# Altium schematic sheet SH2 - MOTOR CONTROLLER.SchDoc
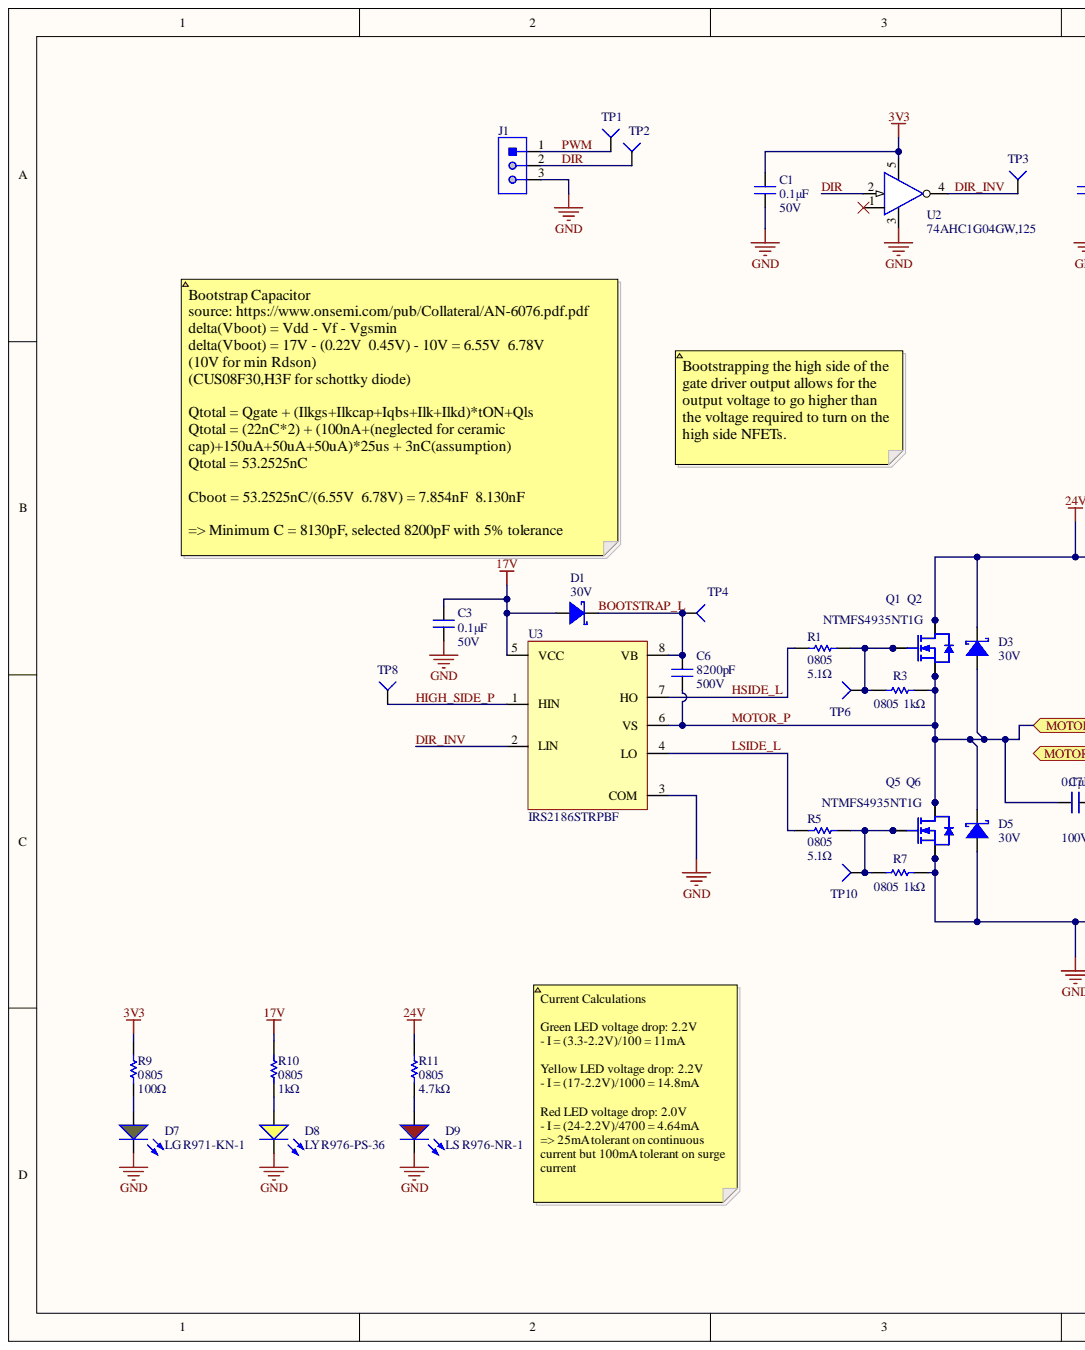
[diagram p0: SH2 - MOTOR CONTROLLER.SchDoc, left]
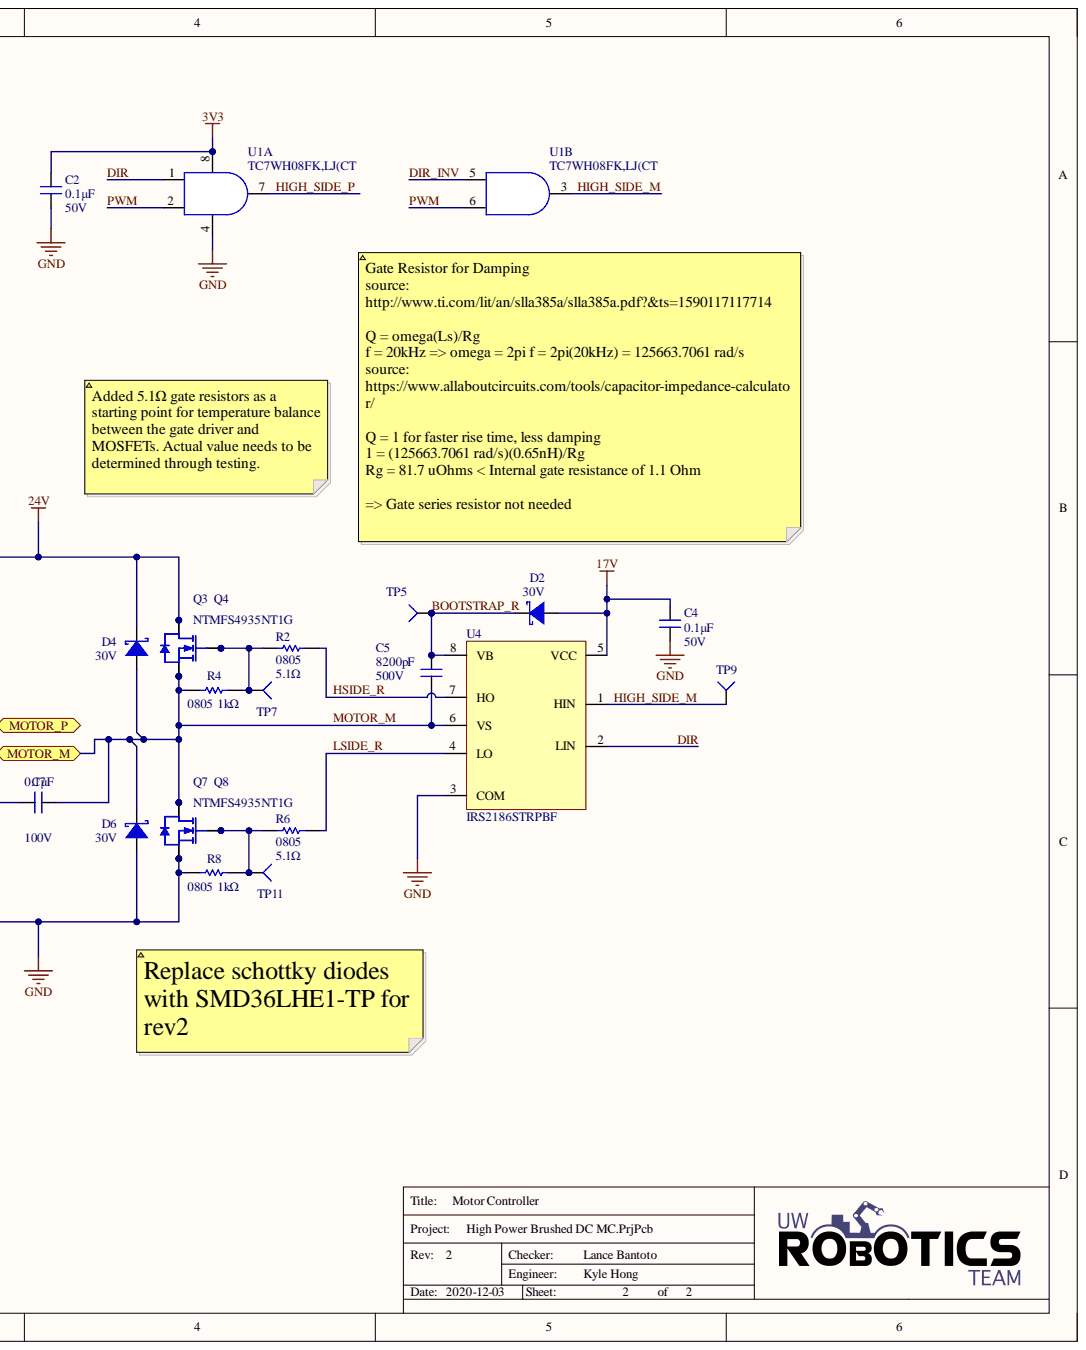
[diagram p1: SH2 - MOTOR CONTROLLER.SchDoc, right]

## Parts
C1  0.1μF  Voltage Rating: 50V  [CAP2012]
C2  0.1μF  Voltage Rating: 50V  [CAP2012]
C3  0.1μF  Voltage Rating: 50V  [CAP2012]
C4  0.1μF  Voltage Rating: 50V  [CAP2012]
C5  value 8200pF  Voltage Rating: 500V  [CAP1206]  (hidden comment: CAP_8200pF_500V_1206)
C6  value 8200pF  Voltage Rating: 500V  [CAP1206]  (hidden comment: CAP_8200pF_500V_1206)
C7  value 0.1μF  Voltage Rating: 100V  [MKS2D031001A00MSSD]  (hidden comment: CAP_0.1uF_100V_FILM)
D1  Vr (Max): 30V  [SOD-323_UNIDIR]  (hidden comment: DIO_SCHOTTKY_30V_800mA)
D2  Vr (Max): 30V  [SOD-323_UNIDIR]  (hidden comment: DIO_SCHOTTKY_30V_800mA)
D3  Vr (Max): 30V  [SOD-323_UNIDIR]  (hidden comment: DIO_SCHOTTKY_30V_800mA)
D4  Vr (Max): 30V  [SOD-323_UNIDIR]  (hidden comment: DIO_SCHOTTKY_30V_800mA)
D5  Vr (Max): 30V  [SOD-323_UNIDIR]  (hidden comment: DIO_SCHOTTKY_30V_800mA)
D6  Vr (Max): 30V  [SOD-323_UNIDIR]  (hidden comment: DIO_SCHOTTKY_30V_800mA)
D7  LG R971-KN-1  [LED_0805_GREEN]
D8  LY R976-PS-36  [LED_0805_YELLOW]
D9  LS R976-NR-1  [LED_0805_RED]
J1  CON_3M_TERM  [1935174]  (value not drawn)
Q1  NTMFS4935NT1G  [SO8-FL]
Q2  NTMFS4935NT1G  [SO8-FL]
Q3  NTMFS4935NT1G  [SO8-FL]
Q4  NTMFS4935NT1G  [SO8-FL]
Q5  NTMFS4935NT1G  [SO8-FL]
Q6  NTMFS4935NT1G  [SO8-FL]
Q7  NTMFS4935NT1G  [SO8-FL]
Q8  NTMFS4935NT1G  [SO8-FL]
R1  value 5.1Ω  Case Code (Imperial): 0805  [RESC2012X60N]  (hidden comment: RES_5.1Ω_0805)
R2  value 5.1Ω  Case Code (Imperial): 0805  [RESC2012X60N]  (hidden comment: RES_5.1Ω_0805)
R3  1kΩ  Case Code (Imperial): 0805  [RESC2012X60N]
R4  1kΩ  Case Code (Imperial): 0805  [RESC2012X60N]
R5  value 5.1Ω  Case Code (Imperial): 0805  [RESC2012X60N]  (hidden comment: RES_5.1Ω_0805)
R6  value 5.1Ω  Case Code (Imperial): 0805  [RESC2012X60N]  (hidden comment: RES_5.1Ω_0805)
R7  1kΩ  Case Code (Imperial): 0805  [RESC2012X60N]
R8  1kΩ  Case Code (Imperial): 0805  [RESC2012X60N]
R9  value 100Ω  Case Code (Imperial): 0805  [RESC2012X60N]  (hidden comment: RES_100Ω_0805)
R10  1kΩ  Case Code (Imperial): 0805  [RESC2012X60N]
R11  value 4.7kΩ  Case Code (Imperial): 0805  [RESC2012X60N]  (hidden comment: RES_4.7kΩ_0805)
TP1  TESTPOINT_1MM_CIRCLE  [TESTPOINT_CIRCLE_1MM]  (value not drawn)  (no BOM)
TP2  TESTPOINT_1MM_CIRCLE  [TESTPOINT_CIRCLE_1MM]  (value not drawn)  (no BOM)
TP3  TESTPOINT_1MM_CIRCLE  [TESTPOINT_CIRCLE_1MM]  (value not drawn)  (no BOM)
TP4  TESTPOINT_1MM_CIRCLE  [TESTPOINT_CIRCLE_1MM]  (value not drawn)  (no BOM)
TP5  TESTPOINT_1MM_CIRCLE  [TESTPOINT_CIRCLE_1MM]  (value not drawn)  (no BOM)
TP6  TESTPOINT_1MM_CIRCLE  [TESTPOINT_CIRCLE_1MM]  (value not drawn)  (no BOM)
TP7  TESTPOINT_1MM_CIRCLE  [TESTPOINT_CIRCLE_1MM]  (value not drawn)  (no BOM)
TP8  TESTPOINT_1MM_CIRCLE  [TESTPOINT_CIRCLE_1MM]  (value not drawn)  (no BOM)
TP9  TESTPOINT_1MM_CIRCLE  [TESTPOINT_CIRCLE_1MM]  (value not drawn)  (no BOM)
TP10  TESTPOINT_1MM_CIRCLE  [TESTPOINT_CIRCLE_1MM]  (value not drawn)  (no BOM)
TP11  TESTPOINT_1MM_CIRCLE  [TESTPOINT_CIRCLE_1MM]  (value not drawn)  (no BOM)
U1  TC7WH08FK,LJ(CT  [8-VFSOP]  (parts A,B)
U2  74AHC1G04GW,125  [SOT353]
U3  IRS2186STRPBF  [8-SOIC_M]
U4  IRS2186STRPBF  [8-SOIC_M]

## Ports
MOTOR_M, MOTOR_P

## Nets
3V3: C1.2 C2.2 R9.2 U1.8 U2.5
17V: C3.2 C4.2 D1.1 D2.1 R10.2 U3.5(VCC) U4.5(VCC)
24V: D3.2 D4.2 Q1.3 Q2.3 Q3.3 Q4.3 R11.2
GND: C1.1 C2.1 C3.1 C4.1 D5.1 D6.1 D7.2 D8.2 D9.2 J1.3 Q5.1 Q6.1 Q7.1 Q8.1 R7.2 R8.1 U1.4 U2.3 U3.3(COM) U4.3(COM)
BOOTSTRAP_L: C6.2 D1.2 TP4.1 U3.8(VB)
BOOTSTRAP_R: C5.2 D2.2 TP5.1 U4.8(VB)
DIR: J1.2 TP2.1 U1.1 U2.2 U4.2(LIN)
DIR_INV: TP3.1 U1.5 U2.4 U3.2(LIN)
HIGH_SIDE_M: TP9.1 U1.3 U4.1(HIN)
HIGH_SIDE_P: TP8.1 U1.7 U3.1(HIN)
HSIDE_L: R1.1 U3.7(HO)
HSIDE_R: R2.2 U4.7(HO)
LSIDE_L: R5.1 U3.4(LO)
LSIDE_R: R6.2 U4.4(LO)
MOTOR_M: C5.1 C7.2 D4.1 D6.2 Q3.1 Q4.1 Q7.3 Q8.3 R4.1 U4.6(VS) ; port MOTOR_M
MOTOR_P: C6.1 C7.1 D3.1 D5.2 Q1.1 Q2.1 Q5.3 Q6.3 R3.2 U3.6(VS) ; port MOTOR_P
PWM: J1.1 TP1.1 U1.2 U1.6
NetD7_1: D7.1 R9.1
NetD8_1: D8.1 R10.1
NetD9_1: D9.1 R11.1
NetQ1_2: Q1.2 Q2.2 R1.2 R3.1 TP6.1
NetQ3_2: Q3.2 Q4.2 R2.1 R4.2 TP7.1
NetQ5_2: Q5.2 Q6.2 R5.2 R7.1 TP10.1
NetQ7_2: Q7.2 Q8.2 R6.1 R8.2 TP11.1

Not connected: U2 1

## Library data of the parts
C1-C4 = CAP_0.1uF_50V_0805: CAP CER 0.1UF 50V X7R 0805; Manufacturer 1: Murata Electronics; Manufacturer Part Number 1: GCD21BR71H104KA01L; Tolerance: 10%; Case Code (Imperial): 0805; Case Code (Metric): 2012; Component Kind: Standard; Depth: 1.25mm; Dielectric: X7R; Height: 1.25mm; Length: 2mm; Max Operating Temperature: 125°C; Min Operating Temperature: -55°C; Mount: Surface Mount; Package: 0805 (2012 Metric); Ratings: AEC-Q200; Supplier 1: Digi-Key; Supplier Part Number 1: 490-11955-1-ND; Termination: SMD/SMT; Thickness: 0.049inch; Value: 0.1μF; Width: 0.049inch; Library Name: UWRT_Schematic_Library_2020.SchLib
C5 C6 = CAP_8200pF_500V_1206: CAP CER 0.0082uF 500Vdc C0G 5% 1206; Manufacturer 1: KEMET; Manufacturer Part Number 1: C1206C822JCGACAUTO; Dielectric: C0G, NP0; Case Code (Imperial): 1206; Case Code (Metric): 3216; Category: Ceramic Capacitors; Component Kind: Standard; Package: 1206 (3216 Metric); Supplier 1: Digi-Key; Supplier Part Number 1: 399-14847-1-ND; Tolerance: 5%; Voltage Rating (DC): 500V; Library Name: UWRT_Schematic_Library_2020.SchLib
C7 = CAP_0.1uF_100V_FILM: CAP FILM 0.1UF 100V RADIAL; Manufacturer 1: WIMA; Dielectric: PET; Lead Diameter: 0.5mm; Tolerance: 20%; Voltage(AC): 63V; Category: Film Capacitors; Component Kind: Standard; Depth: 2.5mm; Lead Pitch: 5mm; Length: 7.2mm; Manufacturer Part Number 1: MKS2D031001A00MSSD; Max Operating Temperature: 100°C; Min Operating Temperature: -55°C; Supplier 1: Digi-Key; Supplier Part Number 1: 1928-1656-ND; Voltage(DC): 100V; Library Name: UWRT_Schematic_Library_2020.SchLib
D1-D6 = DIO_SCHOTTKY_30V_800mA: DIODE SCHOTTKY 30V 800MA USC; Manufacturer 1: Toshiba Semiconductor and Storage; Manufacturer Part Number 1: CUS08F30,H3F; Vf (Max): 220mV; Component Kind: Standard; Io: 800mA; Mount: Surface Mount; Supplier 1: Digi-Key; Supplier Part Number 1: CUS08F30H3FCT-ND; Library Name: UWRT_Schematic_Library_2020.SchLib
D7 = DIO_LED_G: LED GREEN DIFFUSED 0805 SMD; Manufacturer 1: OSRAM; Forward Voltage Drop: 2.2V; Component Kind: Standard; Manufacturer Part Number 1: LG R971-KN-1; Mount: Surface Mount; Package: 0805 (2012 Metric); Supplier 1: Digi-Key; Supplier Part Number 1: 475-1410-1-ND; Library Name: UWRT_Schematic_Library_2020.SchLib
D8 = DIO_LED_Y: LED YELLOW DIFFUSED 0805 SMD; Manufacturer 1: OSRAM; Forward Voltage Drop: 2.2V; Component Kind: Standard; Manufacturer Part Number 1: LY R976-PS-36; Mount: Surface Mount; Package: 0805 (2012 Metric); Supplier 1: Digi-Key; Supplier Part Number 1: 475-2560-1-ND; Library Name: UWRT_Schematic_Library_2020.SchLib
D9 = DIO_LED_R: LED RED DIFFUSED 0805 SMD; Manufacturer 1: OSRAM; Forward Voltage Drop: 2V; Component Kind: Standard; Manufacturer Part Number 1: LS R976-NR-1; Mount: Surface Mount; Package: 0805 (2012 Metric); Supplier 1: Digi-Key; Supplier Part Number 1: 475-1278-1-ND; Library Name: UWRT_Schematic_Library_2020.SchLib
J1 = CON_3M_TERM: TERM BLK 3POS SIDE ENTRY 5MM; Manufacturer 1: Phoenix Contact; Approvals: UL,VDE,CB; Current: 18A; Current Rating: 17.5A; Max Current Rating: 16A; Max Supply Voltage: 250V; Number of Circuits: 3; Number of Levels: 1; Voltage: 300V; Voltage Rating (DC): 320V; Wire Gauge (Max): 26AWG; Wire Gauge (Min): 12AWG; Category: Terminal Blocks; Color: Green; Component Kind: Standard; Contact Material: Copper,Tin; Depth: 9mm; Flammability Rating: UL94 V-0; Height: 11.3mm; Housing Color: Green; Housing Material: Polyamide; Insulation Material: Nylon; Length: 9mm; Manufacturer Part Number 1: 1935174; Material: Nylon; Mount: Through Hole; Number of Contacts: 3; Number of Pins: 3; Number of Poles: 3; Number of Positions: 3; Number of Positions Per Level: 3; Number of Rows: 1; Orientation: Straight; Pitch: 5mm; REACH SVHC: Unknown; Stripping Length: 5mm; Supplier 1: Digi-Key; Supplier Part Number 1: 277-1578-ND; Termination: Solder; Voltage Rating: 250V; Weight: 0.100531oz; Width: 15mm; Wire/Cable Gauge: 26AWG; Library Name: UWRT_Schematic_Library_2020.SchLib
Q1-Q8 = NTMFS4935NT1G: MOSFET N-CH 30V 13A SO8FL; Manufacturer 1: ON Semiconductor; Continuous Drain Current (ID): 93A; Drain to Source Resistance: 3.2mR; Element Configuration: Single; Fall Time: 6.6ns; Gate to Source Voltage (Vgs): 20V; Input Capacitance: 4.85nF; Max Power Dissipation: 48W; Nominal Vgs: 1.63V; Number of Elements: 1; Power Dissipation: 2.63W; Rise Time: 20ns; Turn-Off Delay Time: 27.5ns; Turn-On Delay Time: 16.3ns; Case/Package: SO; Category: MOSFETs; Component Kind: Standard; Contact Plating: Tin,Matte; Drain to Source Breakdown Voltage: 30V; Drain to Source Voltage (Vdss): 30V; Height: 1.1mm; Length: 5.1mm; Manufacturer Part Number 1: NTMFS4935NT1G; Max Operating Temperature: 150°C; Min Operating Temperature: -55°C; Number of Pins: 5; Rds On Max: 3.2mR; REACH SVHC: No SVHC; Supplier 1: Digi-Key; Supplier Part Number 1: NTMFS4935NT1GOSCT-ND; Threshold Voltage: 1.63V; Width: 6.1mm; Library Name: UWRT_Schematic_Library_2020.SchLib
R1 R2 R5 R6 = RES_5.1Ω_0805_5%: RES 5.1 OHM 5% 1/8W 0805; Manufacturer 1: Panasonic; Manufacturer Part Number 1: ERJ-6GEYJ5R1V; Value: 5.1Ω; Case Code (Metric): 2012; Component Kind: Standard; Mount: Surface Mount; Package: 0805 (2012 Metric); Supplier 1: Digi-Key; Supplier Part Number 1: P5.1ACT-ND; Library Name: UWRT_Schematic_Library_2020.SchLib
R3 R4 R7 R8 R10 = RES_1kΩ_0805_1%: RES 1K OHM 1% 1/8W 0805; Manufacturer 1: Yageo; Manufacturer Part Number 1: RC0805FR-071KL; Max Power Dissipation: 125mW; Temperature Coefficient: 25ppm/°C; Voltage Rating: 150V; Case Code (Metric): 2012; Case/Package: 0805; Component Kind: Standard; Composition: Thin Film; Contact Plating: Tin; Depth: 1.25mm; Features: Moisture Resistant,Automotive AEC-Q200,Anti-Sulfur; Height: 0.022inch; Length: 2mm; Max Operating Temperature: 155°C; Military Standard: Not; Min Operating Temperature: -55°C; Mount: Surface Mount; Number of Pins: 2; Number of Terminations: 2; Package: 0805 (2012 Metric); Power Rating: 125mW; REACH SVHC: Unknown; Resistance: 1K; Supplier 1: Digi-Key; Supplier Part Number 1: 311-1.00KCRCT-ND; Termination: SMD/SMT; Tolerance: 1%; Value: 1kΩ; Voltage Rating (DC): 150V; Weight: 0.000194oz; Width: 0.049inch; Library Name: UWRT_Schematic_Library_2020.SchLib
R9 = RES_100Ω_0805_1%: RES 100 OHM 1% 1/8W 0805; Manufacturer 1: Yageo; Manufacturer Part Number 1: RC0805FR-07100RL; Power Rating: 125mW; Case Code (Metric): 2012; Component Kind: Standard; Mount: Surface Mount; Package: 0805 (2012 Metric); Supplier 1: Digi-Key; Supplier Part Number 1: 311-100CRCT-ND; Library Name: UWRT_Schematic_Library_2020.SchLib
R11 = RES_4.7kΩ_0805_5%: RES 4.7K OHM 5% 1/8W 0805; Manufacturer 1: Yageo; Manufacturer Part Number 1: RC0805JR-074K7L; Power Rating: 125mW; Resistance: 4.7kR; Temperature Coefficient: 100ppm/°C; Voltage Rating: 150V; Case Code (Metric): 2012; Component Kind: Standard; Composition: Thick Film; Contact Plating: Tin,Lead,Matte; Depth: 1.25mm; Length: 2mm; Max Operating Temperature: 155°C; Military Standard: Not; Min Operating Temperature: -55°C; Mount: Surface Mount; Package: 0805 (2012 Metric); REACH SVHC: Unknown; Supplier 1: Digi-Key; Supplier Part Number 1: 311-4.7KARCT-ND; Termination: SMD/SMT; Tolerance: 5%; Library Name: UWRT_Schematic_Library_2020.SchLib
TP1-TP11 = TESTPOINT_1MM_CIRCLE: TESTPOINT 1MM CIRCLE; Library Name: UWRT_Schematic_Library_2020.SchLib
U1 = TC7WH08FK,LJ(CT: AND Gate 2-Element 2-IN CMOS Automotive 8-Pin SSOP T/R; Manufacturer 1: Toshiba; High Level Output Current: 8mA; Number of Circuits: 2; Propagation Delay: 7.9ns; Quiescent Current: 2uA; Category: Logic Gates; Component Kind: Standard; Logic Function: AND; Low Level Output Current: 8mA; Manufacturer Part Number 1: TC7WH08FK,LJ(CT; Max Operating Temperature: 85°C; Min Operating Temperature: -40°C; Mount: Surface Mount; Number of Inputs: 2; Number of Pins: 8; Supplier 1: Digi-Key; Supplier Part Number 1: TC7WH08FKLJ(CTCT-ND; Library Name: UWRT_Schematic_Library_2020.SchLib
U2 = 74AHC1G04GW,125: IC INVERTER 1CH 1-INP 5TSSOP; Manufacturer 1: Nexperia; Component Kind: Standard; Manufacturer Part Number 1: 74AHC1G04GW,125; Supplier 1: Digi-Key; Supplier Part Number 1: 1727-3147-1-ND; Library Name: UWRT_Schematic_Library_2020.SchLib
U3 U4 = IRS2186STRPBF: IC DRIVER HI/LO SIDE 600V 8-SOIC; Manufacturer 1: International Rectifier; Fall Time: 30ns; Max Output Current: 4A; Max Power Dissipation: 625mW; Max Supply Current: 240uA; Max Supply Voltage: 20V; Min Supply Voltage: 10V; Number of Drivers: 2; Propagation Delay: 250ns; Rise Time: 38ns; Turn-Off Delay Time: 170ns; Case/Package: SOIC; Category: Gate Drivers; Component Kind: Standard; Manufacturer Part Number 1: IRS2186STRPBF; Max Operating Temperature: 125°C; Min Operating Temperature: -40°C; Mount: Surface Mount; Nominal Supply Current: 240uA; Number of Outputs: 2; Number of Pins: 8; Output Current: 4A; Power Dissipation: 625mW; Supplier 1: Digi-Key; Supplier Part Number 1: IRS2186STRPBFCT-ND; Turn-On Delay Time: 170ns; Library Name: UWRT_Schematic_Library_2020.SchLib
